# Altium schematic sheet NFC_click_v100.SchDoc
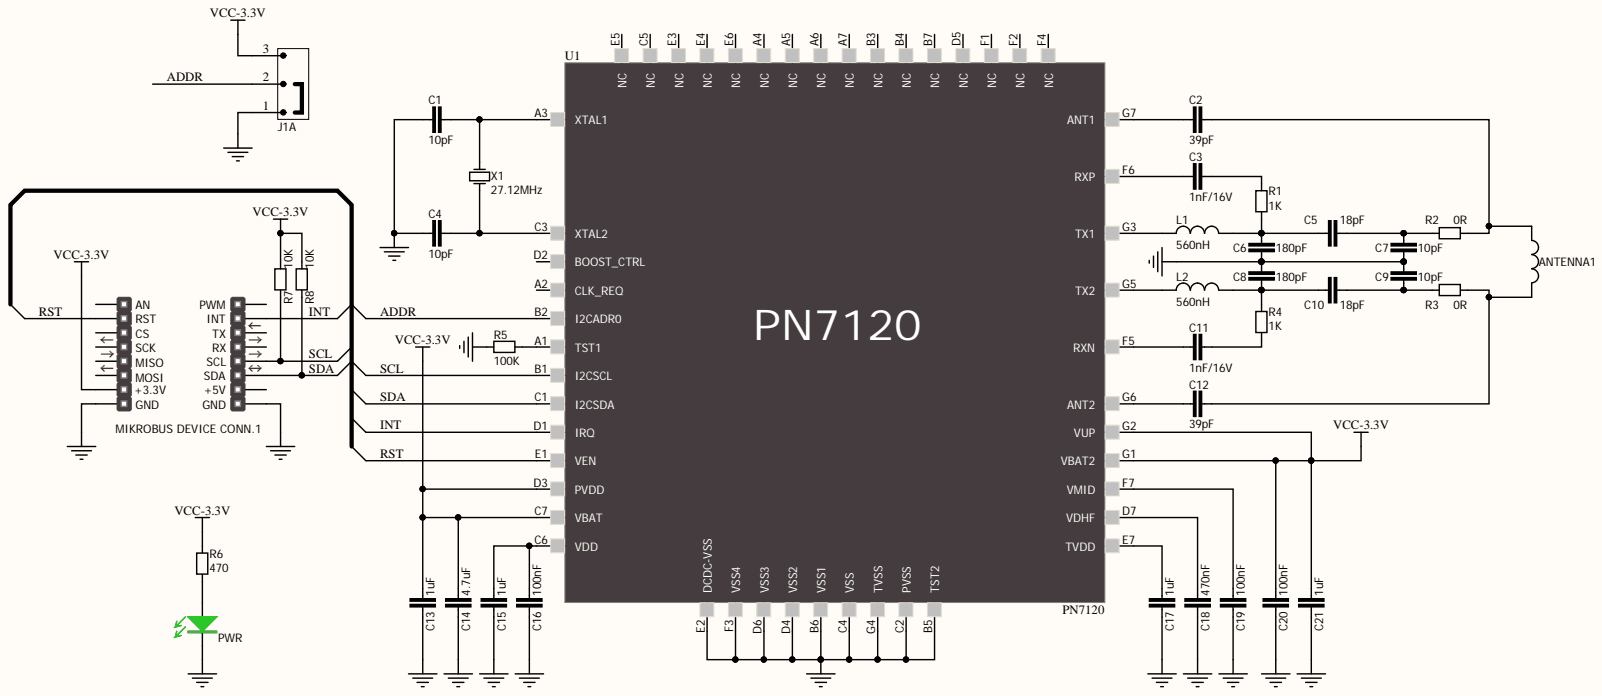
[diagram p0: NFC_click_v100.SchDoc]

## Parts
ANTENNA1  INDUCTOR  [INDUCTIVE ANTENNA]  (value not drawn)  (no BOM)
C1  10pF  [0402C]
C2  39pF  [0402C]
C3  1nF/16V  [0402C]
C4  10pF  [0402C]
C5  18pF  [0402C]
C6  180pF  [0402C]
C7  10pF  [0402C]
C8  180pF  [0402C]
C9  10pF  [0402C]
C10  18pF  [0402C]
C11  1nF/16V  [0402C]
C12  39pF  [0402C]
C13  1uF  [0402C]
C14  4.7uF  [0603_C_V4]
C15  1uF  [0402C]
C16  100nF  [0402C]
C17  1uF  [0402C]
C18  470nF  [0402C]
C19  100nF  [0402C]
C20  100nF  [0402C]
C21  1uF  [0402C]
J1  0 ohm  [0805 DUAL v2]  (value not drawn)  (part A)
L1  560nH  [0603_C_V4]
L2  560nH  [0603_C_V4]
MIKROBUS_DEVICE_CONN.1  MIKROBUS DEVICE CONN.  [MIKRO_BUS_LARGE]
PWR  GREEN  [0805 - LED]  (value not drawn)
R1  1K  [0402R]
R2  0R  [0402R]
R3  0R  [0402R]
R4  1K  [0402R]
R5  100K  [0402R]
R6  470  [0402R]
R7  10K  [0402R]
R8  10K  [0402R]
U1  PN7120  [PN7120]
X1  27.12MHz  [CRYSTAL ABM7 SMD V2]

## Nets
GND: C1.1 C4.1 C6.1 C7.2 C8.2 C9.1 C13.1 C14.1 C15.1 C16.1 C17.1 C18.1 C19.1 C20.1 C21.1 J1.1 MIKROBUS_DEVICE_CONN.1.8(GND) MIKROBUS_DEVICE_CONN.1.9(GND) PWR.2 R5.2 U1.B5(TST2) U1.B6(VSS1) U1.C2(PVSS) U1.C4(VSS) U1.D4(VSS2) U1.D6(VSS3) U1.E2(DCDC-VSS) U1.F3(VSS4) U1.G4(TVSS)
VCC-3.3V: C13.2 C14.2 C20.2 C21.2 J1.3 MIKROBUS_DEVICE_CONN.1.7(+3.3V) R6.2 R7.2 R8.2 U1.C7(VBAT) U1.D3(PVDD) U1.G1(VBAT2) U1.G2(VUP)
ADDR: J1.2 U1.B2(I2CADR0)
INT: MIKROBUS_DEVICE_CONN.1.15(INT) U1.D1(IRQ)
RST: MIKROBUS_DEVICE_CONN.1.2(RST) U1.E1(VEN)
SCL: MIKROBUS_DEVICE_CONN.1.12(SCL) R7.1 U1.B1(I2CSCL)
SDA: MIKROBUS_DEVICE_CONN.1.11(SDA) R8.1 U1.C1(I2CSDA)
NetANTENNA1_1: ANTENNA1.1 C2.2 R2.1
NetANTENNA1_2: ANTENNA1.2 C12.2 R3.1
NetC1_2: C1.2 U1.A3(XTAL1) X1.2
NetC2_1: C2.1 U1.G7(ANT1)
NetC3_1: C3.1 U1.F6(RXP)
NetC3_2: C3.2 R1.2
NetC4_2: C4.2 U1.C3(XTAL2) X1.1
NetC5_1: C5.1 C7.1 R2.2
NetC5_2: C5.2 C6.2 L1.2 R1.1
NetC8_1: C8.1 C10.2 L2.2 R4.2
NetC9_2: C9.2 C10.1 R3.2
NetC11_1: C11.1 U1.F5(RXN)
NetC11_2: C11.2 R4.1
NetC12_1: C12.1 U1.G6(ANT2)
NetC15_2: C15.2 C16.2 U1.C6(VDD)
NetC17_2: C17.2 U1.E7(TVDD)
NetC18_2: C18.2 U1.D7(VDHF)
NetC19_2: C19.2 U1.F7(VMID)
NetL1_1: L1.1 U1.G3(TX1)
NetL2_1: L2.1 U1.G5(TX2)
NetPWR_1: PWR.1 R6.1
NetR5_1: R5.1 U1.A1(TST1)
N00004:

Not connected: MIKROBUS_DEVICE_CONN.1 1(AN) 3(CS) 4(SCK) 5(MISO) 6(MOSI) 10(+5V) 13(RX) 14(TX) 16(PWM); U1 A2(CLK_REQ) A4(NC) A5(NC) A6(NC) A7(NC) B3(NC) B4(NC) B7(NC) C5(NC) D2(BOOST_CTRL) D5(NC) E3(NC) E4(NC) E5(NC) E6(NC) F1(NC) F2(NC) F4(NC)

## Library data of the parts
C1-C21 = CAP NP: Capacitor; Component Kind: Standard; SMD: Yes; Library Name: EASY-PIC PRO7.SCHLIB
J1 = JUMPER2: Jumper, two states, 1x3; Component Kind: Standard; SMD: Yes; Library Name: SCH_Rega.SchLib
L1 L2 = INDUCTOR: Component Kind: Standard; Description: Inductor; DigiKey Part No.: 587-2097-1-ND; SMD: Yes; Library Name: FLIPnCLICK_v100.SCHLIB
MIKROBUS_DEVICE_CONN.1 = MIKROBUS DEVICE CONN.: mikroBUS Target Header; Component Kind: Standard; Library Name: SCH_Rega.SchLib
PWR = LED GREEN: LE diode, Green; Component Kind: Standard; SMD: Yes; Library Name: SCH_Rega.SchLib
R1-R8 = RES: Resistor; Component Kind: Standard; SMD: Yes
  R7 R8: Library Name: TEMP_click_v100.SCHLIB
  R1-R6: Library Name: EASY-PIC PRO7.SCHLIB
X1 = CRYSTAL: Crystal Oscillator; Component Kind: Standard; Library Name: TI LaunchPad_v100.SCHLIB; SMD: Yes
ANTENNA1 = INDUCTOR: Description: Inductor; Library Name: mikroE_SCH_Library_Predrag.SchLib
U1 = PN7120: Component Kind: Standard; Description: PN7120; SMD: Yes; Library Name: SCH_Rega.SchLib
